# Altium schematic sheet TemperatureController.SchDoc(TemperatureController)
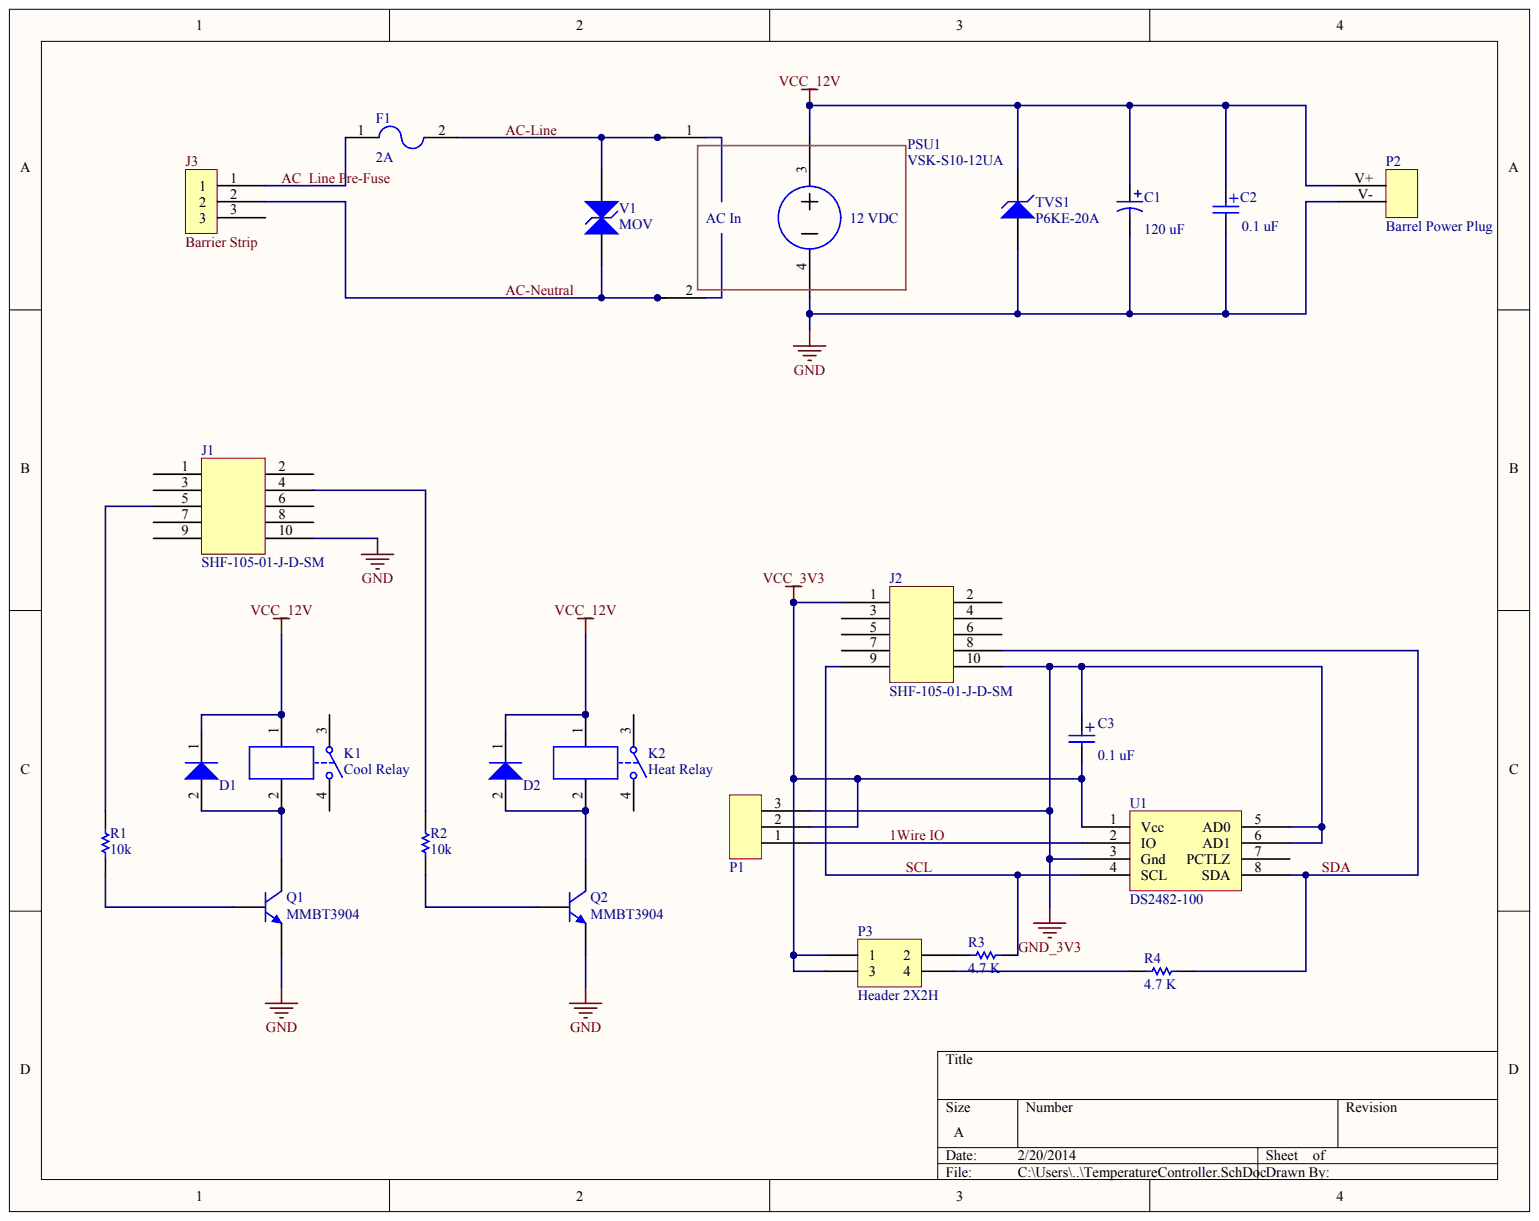
[diagram p0: TemperatureController.SchDoc]

## Parts
C1  value 120 uF  (hidden comment: Capacitor, Polarized Axial)
C2  value 0.1 uF  (hidden comment: Bypass Cap)
C3  value 0.1 uF  (hidden comment: Bypass Cap)
D1  SMD Diode  (value not drawn)
D2  SMD Diode  (value not drawn)
F1  value 2A  (hidden comment: Panel Mount Fuze)
J1  SHF-105-01-J-D-SM
J2  SHF-105-01-J-D-SM
J3  Barrier Strip
K1  Cool Relay
K2  Heat Relay
P1  1x3 Header  (value not drawn)
P2  Barrel Power Plug
P3  Header 2X2H
PSU1  VSK-S10-12UA
Q1  MMBT3904
Q2  MMBT3904
R1  10k
R2  10k
R3  4.7 K
R4  4.7 K
TVS1  P6KE-20A
U1  DS2482-100
V1  MOV

## Nets
GND: C1.2 C2.2 J1.10 P2.V- PSU1.4 Q1.1 Q2.1 TVS1.1
GND_3V3: C3.1 J2.10 P1.3 U1.3(Gnd) U1.5(AD0) U1.6(AD1)
VCC_3V3: C3.2 J2.1 P1.2 P3.1 P3.3 U1.1(Vcc)
VCC_12V: C1.1 C2.1 D1.1 D2.1 K1.1 K2.1 P2.V+ PSU1.3 TVS1.2
1WIRE IO: P1.1 U1.2(IO)
AC-LINE: F1.2 PSU1.1 V1.2
AC-NEUTRAL: J3.2 PSU1.2 V1.1
AC_LINE PRE-FUSE: F1.1 J3.1
SCL: J2.9 R3.2 U1.4(SCL)
SDA: J2.8 R4.1 U1.8(SDA)
NetD1_2: D1.2 K1.2 Q1.3
NetD2_2: D2.2 K2.2 Q2.3
NetJ1_4: J1.4 R2.2
NetJ1_5: J1.5 R1.2
NetP3_2: P3.2 R3.1
NetP3_4: P3.4 R4.2
NetQ1_2: Q1.2 R1.1
NetQ2_2: Q2.2 R2.1

Not connected: J1 1 2 3 6 7 8 9; J2 2 3 4 5 6 7; J3 3; K1 3 4; K2 3 4; U1 7(PCTLZ)

## Library data of the parts
PSU1 = (no symbol name): Value: 12V; ComponentLink1Description: Manufacturer Link; ComponentLink1URL: http://www.cui.com/; ComponentLink2Description: Datasheet
V1 = (no symbol name): Value: 150 V; ComponentLink1Description: Manufacturer Link; ComponentLink2Description: Datasheet
C1-C3 D1 D2 F1 J1-J3 K1 K2 P1-P3 Q1 Q2 R1-R4 TVS1 U1 = (no symbol name)
  C1: Published: 8-Jun-2000; LatestRevisionDate: 17-Jul-2002; LatestRevisionNote: Re-released for DXP Platform.; PackageReference: POLAR0.8; PackageDescription: Polarized Capacitor; 2 Leads
  C2 C3 F1 P1 P2: ComponentLink1Description: Manufacturer Link
  J1 J2: ComponentLink1Description: Manufacturer Link; ComponentLink1URL: http://www.samtec.com; ComponentLink2Description: Datasheet
  J3: ComponentLink1Description: Manufacturer Link; ComponentLink1URL: http://www.Molex.com/; ComponentLink2Description: Datasheet
  K1 K2: ComponentLink1Description: Manufacturer Link; ComponentLink1URL: http://www.Omron.com/; ComponentLink2Description: Datasheet
  P3: LatestRevisionDate: 17-Jul-2002; LatestRevisionNote: Re-released for DXP Platform.
  R1 R2: Value: 10k
  R3 R4: Value: 4.7 K
  TVS1: Published: 17-Dec-2003; DatasheetDocument: 29-Apr-2002; ComponentLink1Description: Manufacturer Link; ComponentLink2Description: Datasheet
  U1: ComponentLink1Description: Manufacturer Link; ComponentLink2Description: Datasheet
  C1 P3: Publisher: Altium Limited
